# Altium schematic sheet PWR.SchDoc(PWR)
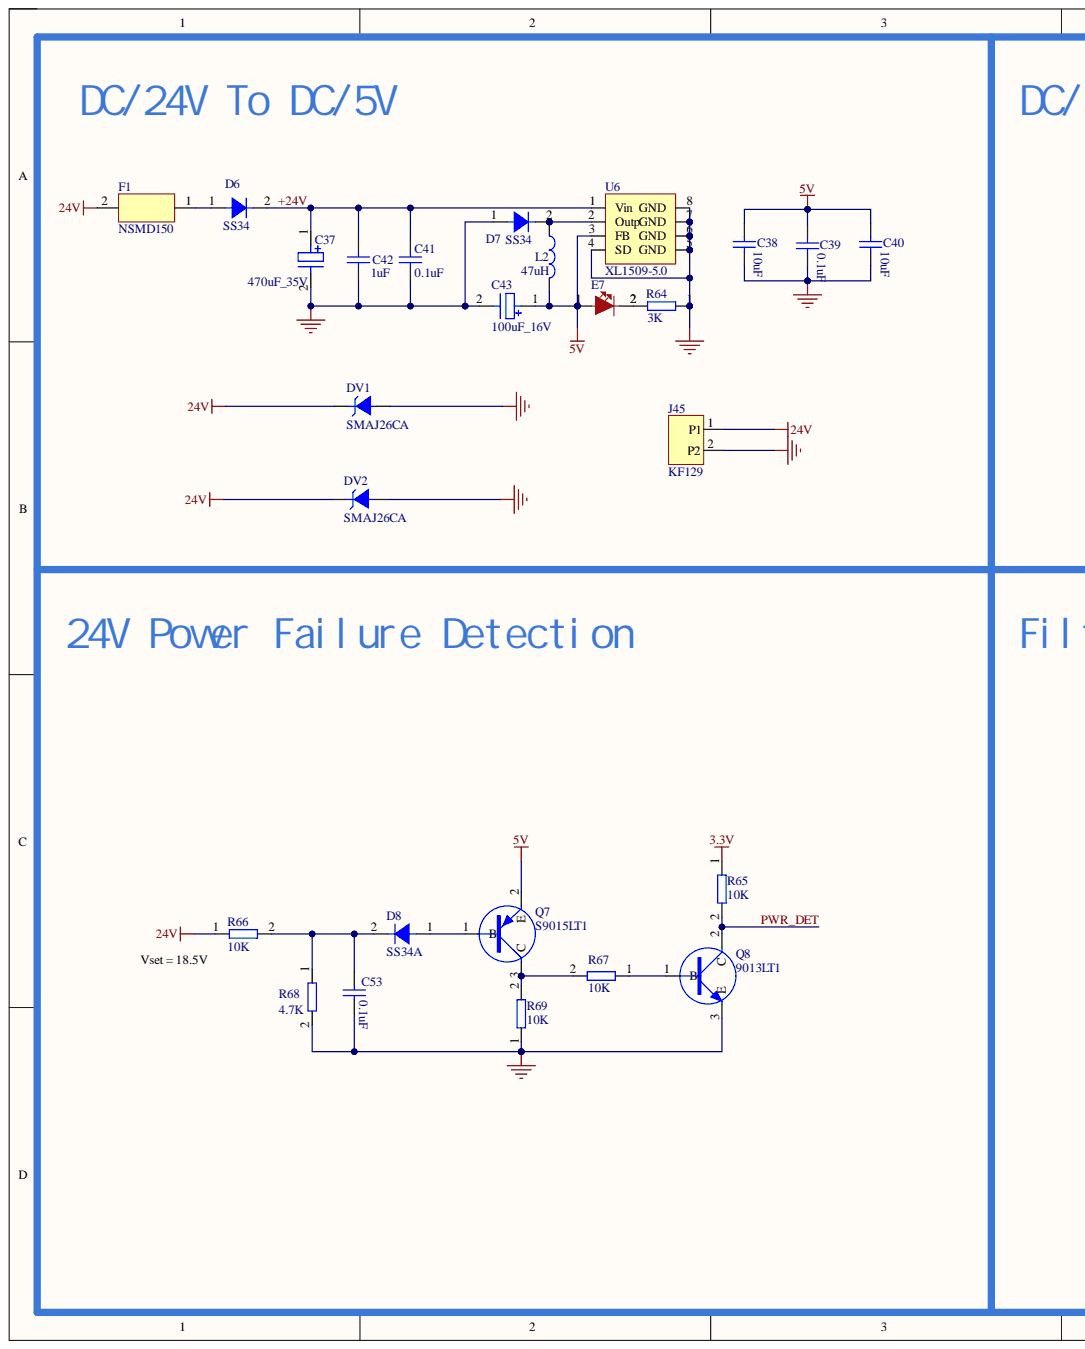
[diagram p0: PWR.SchDoc, left]
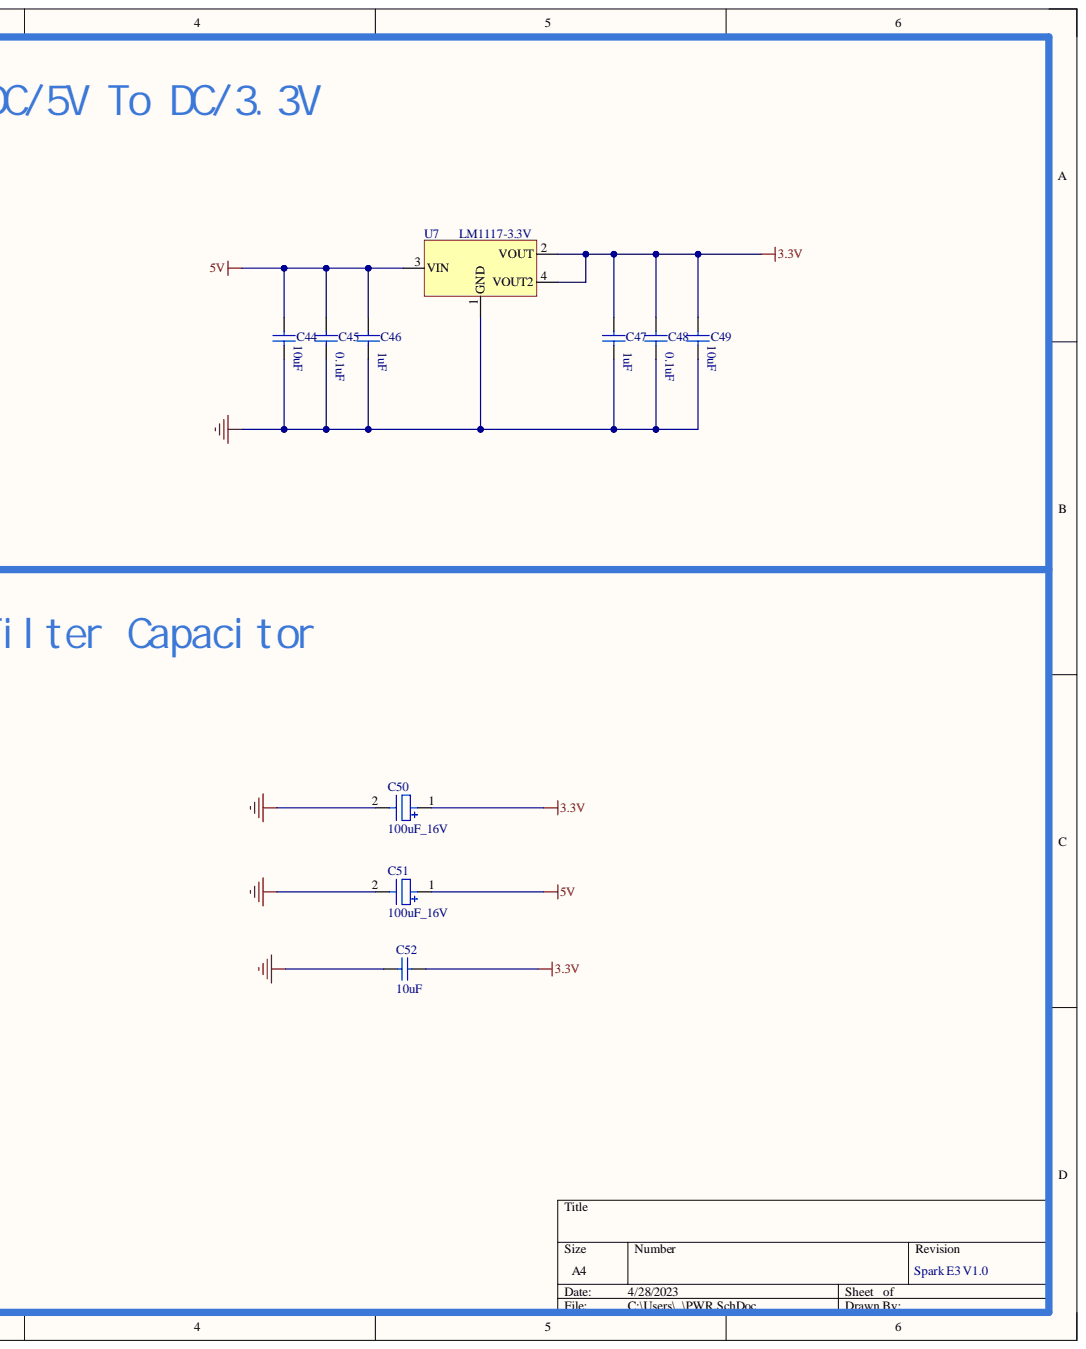
[diagram p1: PWR.SchDoc, right]

## Parts
C37  470uF_35V  [SMT-35v/470uf]
C38  10uF  [C0805]
C39  0.1uF  [C0603]
C40  10uF  [C0805]
C41  0.1uF  [C0603]
C42  1uF  [C0603]
C43  100uF_16V  [SMT-100uF/16V -6.3mm*7.7mm]
C44  10uF  [C0805]
C45  0.1uF  [C0603]
C46  1uF  [C0603]
C47  1uF  [C0603]
C48  0.1uF  [C0603]
C49  10uF  [C0805]
C50  100uF_16V  [SMT-100uF/16V -6.3mm*7.7mm]
C51  100uF_16V  [SMT-100uF/16V -6.3mm*7.7mm]
C52  10uF  [C0805]
C53  0.1uF  [C0603]
D6  SS34  [SS34]
D7  SS34  [SS34]
D8  SS34A  [SS34]
DV1  SMAJ26CA  [SS34]
DV2  SMAJ26CA  [SS34]
E7  LED红  [0603 - LED-R]  (value not drawn)
F1  NSMD150  [SMD3216]
J45  KF129  [KF129-2P]
L2  47uH  [L/4*4*3]
Q7  S9015LT1  [SMT-PNP]
Q8  9013LT1  [SMT-NPN]
R64  3K  [R0603]
R65  10K  [R0603]
R66  10K  [R0603]
R67  10K  [R0603]
R68  4.7K  [R0603]
R69  10K  [R0603]
U6  XL1509-5.0  [SOP-8]
U7  LM1117-3.3V  [SMT/1117]

## Nets
3.3V: C47.1 C48.1 C49.1 C50.1 C52.1 R65.1 U7.2(VOUT) U7.4(VOUT2)
5V: C38.1 C39.1 C40.1 C43.1 C44.1 C45.1 C46.1 C51.1 E7.1 L2.2 Q7.2 U6.3(FB) U7.3(VIN)
GND: C37.2 C38.2 C39.2 C40.2 C41.2 C42.1 C43.2 C44.2 C45.2 C46.2 C47.2 C48.2 C49.2 C50.2 C51.2 C52.2 C53.2 D7.1 DV1.1 DV2.1 J45.2 Q8.3 R64.1 R68.2 R69.1 U6.4(SD) U6.5(GND) U6.6(GND) U6.7(GND) U6.8(GND) U7.1(GND)
24V: C37.1 C41.1 C42.2 D6.2 DV1.2 DV2.2 F1.2 J45.1 R66.1 U6.1(Vin)  [also labelled +24V]
PWR_DET: Q8.2 R65.2
NetC53_1: C53.1 D8.2 R66.2 R68.1
NetD6_1: D6.1 F1.1
NetD7_2: D7.2 L2.1 U6.2(Outp)
NetD8_1: D8.1 Q7.1
NetE7_2: E7.2 R64.2
NetQ7_3: Q7.3 R67.2 R69.2
NetQ8_1: Q8.1 R67.1

## Library data of the parts
C37 C43 C50 C51 = 电解电容: Component Kind: Standard; VALUE: ?; Library Name: MYschlib.SchLib
C38-C42 C44-C49 C52 C53 = 电容: Component Kind: Standard; LatestRevisionDate: 17-Jul-2002; LatestRevisionNote: Re-released for DXP Platform.; PackageDescription: Radial Cap, Thru-Hole; 2 Leads; 0.3 in Pin Spacing; PackageReference: RAD-0.3; Published: 8-Jun-2000; Value: ?; Library Name: MYschlib.SchLib; Publisher: Altium Limited
  C38-C41 C44-C49 C52 C53: Signal Integrity: Cap; Simulation: CAP
D6 D7 = 肖特基/SS34: Component Kind: Standard; VALUE: SS34; Library Name: MYschlib.SchLib
D8 = 肖特基/SS34: VALUE: SS34; Component Kind: Standard; Library Name: MYschlib.SchLib
DV1 DV2 = TVS: Component Kind: Standard; Library Name: jietai-ADLB.SchLib
E7 = LED红灯: Component Kind: Standard; Library Name: MYschlib.SchLib
F1 = 自恢复保险丝: Component Kind: Standard; Library Name: MYschlib.SchLib
J45 = HEAD1×2: Component Kind: Standard; Library Name: jietai-ADLB.SchLib
L2 = 电感: Component Kind: Standard; Library Name: MYschlib.SchLib
Q7 = 三极管/PNP/9012: VALUE: 9012; Component Kind: Standard; Library Name: MYschlib.SchLib
Q8 = 三极管/NPN/9014: Component Kind: Standard; Library Name: MYschlib.SchLib
R64 = 电阻: value: 510; Component Kind: Standard; Library Name: MYschlib.SchLib
R65-R69 = 电阻: Component Kind: Standard; value: ?; Library Name: MYschlib.SchLib
U6 = 电源芯片/XL1509: Component Kind: Standard; Library Name: MYschlib.SchLib
U7 = LM1117-3.3V: Component Kind: Standard; Library Name: jietai-ADLB.SchLib
